# Altium schematic sheet Batt_Protection.SchDoc
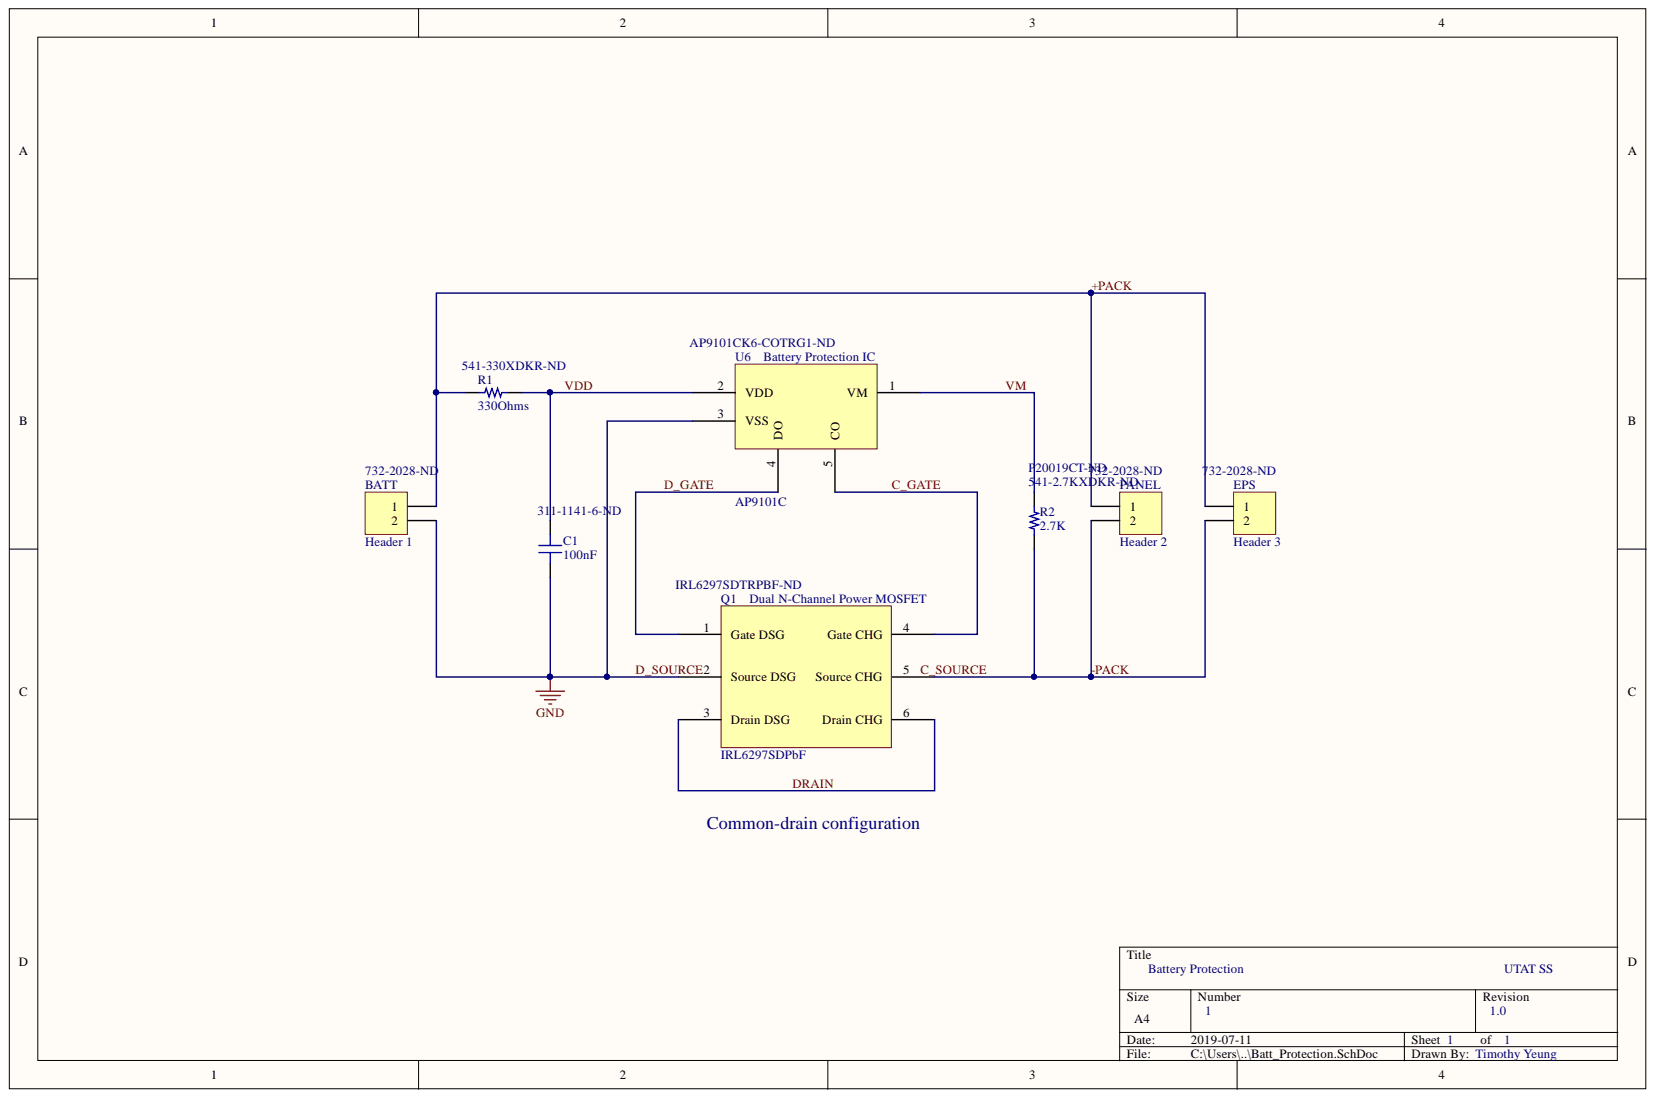
[diagram p0: Batt_Protection.SchDoc]

## Parts
BATT  Header 1  Supplier Part Number 1: 732-2028-ND  [SAM9552-ND]
C1  100nF  Supplier Part Number 1: 311-1141-6-ND  [1608[0603]]
EPS  Header 3  Supplier Part Number 1: 732-2028-ND  [CON2_SCREW_5.00MM_691102710002]
PANEL  Header 2  Supplier Part Number 1: 732-2028-ND  [CON2_SCREW_5.00MM_691102710002]
Q1  IRL6297SDPbF  FET Type: 2 N-Channel  Supplier Part Number 1: IRL6297SDTRPBF-ND  [IRL6297SDPbF]
R1  330Ohms  Supplier Part Number 1: 541-330XDKR-ND  [1608[0603]]
R2  2.7K  Supplier Part Number 1: 541-2.7KXDKR-ND  Supplier Part Number 2: P20019CT-ND  [1608[0603]]
U6  AP9101C  Supplier Part Number 1: AP9101CK6-COTRG1-ND  [AP9101C-776313]

## Nets
+PACK: BATT.1 EPS.1 PANEL.1 R1.1
-PACK: EPS.2 PANEL.2 Q1.5(Source_CHG) R2.1
C_GATE: Q1.4(Gate_CHG) U6.5(CO)
D_GATE: Q1.1(Gate_DSG) U6.4(DO)
D_SOURCE: BATT.2 C1.1 Q1.2(Source_DSG) U6.3(VSS)
DRAIN: Q1.3(Drain_DSG) Q1.6(Drain_CHG)
VDD: C1.2 R1.2 U6.2(VDD)
VM: R2.2 U6.1(VM)
C_SOURCE:

## Library data of the parts
BATT EPS PANEL = Header 2: Header, 2-Pin; Manufacturer: Wurth Electronics; Manufacturer Part Number: 691102710002; Current: 15A; Number of Contacts: 2; Number of Levels: 1; Pitch: 0.197inch; Plating: Steel; Stripping Length: -6mm; Voltage: 300V; Wire/Cable Gauge: 22AWG; Wire Gauge (Min): 14AWG; Color: Blue; Component Kind: Standard; ComponentLink1Description: https://katalog.we-online.de/em/datasheet/6911027100xx.pdf?src-supplier=Digi-Key; ComponentLink1URL: https://katalog.we-online.de/em/datasheet/6911027100xx.pdf?src-supplier=Digi-Key; ComponentLink2Description: http://www.produktinfo.conrad.com/datenblaetter/1000000-1099999/001088592-da-01-en-WR_TBL_TERMINAL_BLOCK102_691102710002.pdf?src-supplier=Conrad; ComponentLink2URL: http://www.produktinfo.conrad.com/datenblaetter/1000000-1099999/001088592-da-01-en-WR_TBL_TERMINAL_BLOCK102_691102710002.pdf?src-supplier=Conrad; Contact Material: Brass; Contact Plating: Tin; Current Rating: 15A; Height: 13.4mm; Housing Material: Nylon; LatestRevisionDate: 17-Jul-2002; LatestRevisionNote: Re-released for DXP Platform.; Length: 7.5mm; Library Name: Miscellaneous Connectors.IntLib; Max Operating Temperature: 105°C; Min Operating Temperature: -40°C; Mount: Through Hole; Number of Positions Per Level: 2; Package Quantity: 900; Packaging: Bulk; Pricing 1: 1=0.69, 10=0.616, 50=0.532, 100=0.5 (USD); REACH SVHC: Unknown; Signal Integrity: Connector; Stock 1: 734; Supplier 1: Digi-Key; Termination: Screw; Voltage Rating: 300V; Width: 11.2mm; Wire Gauge (Max): 22AWG; Publisher: Altium Limited
C1 = Capacitor: SMT Capacitor; Manufacturer: Yageo; Manufacturer Part Number: CC0805KRX7R8BB104; Dielectric: X7R; Insulation Resistance: 100GR; Material: Ceramic; Tolerance: 10%; Value: 0.1uF; Voltage Rating: 25V; Capacitance: 100nF; Case/Package: 0805; Case Code (Imperial): 0805; Case Code (Metric): 2012; Component Kind: Standard; ComponentLink1Description: http://www.yageo.com/documents/recent/UPY-GPHC_X7R_6.3V-to-50V_18.pdf?src-supplier=Digi-Key; ComponentLink1URL: http://www.yageo.com/documents/recent/UPY-GPHC_X7R_6.3V-to-50V_18.pdf?src-supplier=Digi-Key; ComponentLink2Description: http://www.farnell.com/datasheets/1489800.pdf?src-supplier=Element14; ComponentLink2URL: http://www.farnell.com/datasheets/1489800.pdf?src-supplier=Element14; ComponentLink3Description: http://www.farnell.com/datasheets/1850775.pdf?src-supplier=Element14; ComponentLink3URL: http://www.farnell.com/datasheets/1850775.pdf?src-supplier=Element14; ComponentLink4Description: https://www.verical.com/server-webapp/html/getDatasheet?partID=330244&src-supplier=Verical; ComponentLink4URL: https://www.verical.com/server-webapp/html/getDatasheet?partID=330244&src-supplier=Verical; ComponentLink5Description: http://www.yageo.com/documents/recent/UPY-GPHC_X7R_6.3V-to-50V_8.pdf?src-supplier=Avnet+Europe; ComponentLink5URL: http://www.yageo.com/documents/recent/UPY-GPHC_X7R_6.3V-to-50V_8.pdf?src-supplier=Avnet+Europe; ComponentLink6Description: http://www.farnell.com/datasheets/1784427.pdf?src-supplier=Element14; ComponentLink6URL: http://www.farnell.com/datasheets/1784427.pdf?src-supplier=Element14; ComponentLink7Description: http://www.farnell.com/datasheets/70765.pdf?src-supplier=Element14; ComponentLink7URL: http://www.farnell.com/datasheets/70765.pdf?src-supplier=Element14; Composition: Ceramic; Depth: 1.25mm; Halogen Free: Halogen Free; Height: 0.85mm; Lead Free: Lead Free; Length: 2mm; Library Name: Passives.SchLib; Max Operating Temperature: 125°C; Min Operating Temperature: -55°C; Mount: Surface Mount; Number of Pins: 2; Package Quantity: 1; Packaging: Tape and Reel; Pricing 1: 1=0.13, 10=0.092, 100=0.0433, 500=0.0304, 1000=0.02516 (USD); Radiation Hardening: No; REACH SVHC: No SVHC; Stock 1: 1166693; Supplier 1: Digi-Key; Termination: SMD/SMT; Thickness: 0.033inch; Voltage Rating (DC): 25V; Width: 0.049inch
Q1 = IRL6297SDPbF: Dual N-Channel Power MOSFET; Manufacturer: International Rectifier; Manufacturer Part Number: IRL6297SDTRPBF; Continuous Drain Current (ID): 15A; Drain to Source Voltage (Vdss): 20V; Input Capacitance: 2.245nF; Max Power Dissipation: 1.7W; Number of Elements: 2; Rds On Max: 4.9mR; Threshold Voltage: 800mV; Component Kind: Standard; ComponentLink1Description: http://www.irf.com/product-info/datasheets/data/irl6297sdpbf.pdf?src-supplier=Digi-Key; ComponentLink1URL: http://www.irf.com/product-info/datasheets/data/irl6297sdpbf.pdf?src-supplier=Digi-Key; Gate to Source Voltage (Vgs): 20V; Lead Free: Lead Free; Library Name: EPS.SchLib; Max Operating Temperature: 150°C; Min Operating Temperature: -40°C; Mount: Surface Mount; Number of Pins: 8; Package Quantity: 4800; Packaging: Tape and Reel; Power Dissipation: 1.7W; REACH SVHC: No SVHC; Stock 1: 0; Supplier 1: Digi-Key
R1 = Resistor: SMT Resistor; Manufacturer: Vishay Dale; Manufacturer Part Number: CRCW2512330RJNEG; Max Power Dissipation: 1W; Resistance: 330R; Temperature Coefficient: 200ppm/°C; Tolerance: 5%; Value: 1K; Voltage Rating: 500V; Case/Package: 2512; Case Code (Imperial): 2512; Case Code (Metric): 6432; Component Kind: Standard; ComponentLink1Description: http://www.vishay.com/docs/20035/dcrcwe3.pdf?src-supplier=Digi-Key; ComponentLink1URL: http://www.vishay.com/docs/20035/dcrcwe3.pdf?src-supplier=Digi-Key; ComponentLink2Description: http://www.vishay.com/docs/20035/dcrcwe3.pdf?src-supplier=Avnet; ComponentLink2URL: http://www.vishay.com/docs/20035/dcrcwe3.pdf?src-supplier=Avnet; ComponentLink3Description: https://www.alliedelec.com/m/d/0c934d6939ccefa68dbe0b96d821c8cf.pdf?src-supplier=Allied+Electronics; ComponentLink3URL: https://www.alliedelec.com/m/d/0c934d6939ccefa68dbe0b96d821c8cf.pdf?src-supplier=Allied+Electronics; ComponentLink4Description: http://www.vishay.com/docs/20035/dcrcwe3.pdf?src-supplier=Future+Electronics; ComponentLink4URL: http://www.vishay.com/docs/20035/dcrcwe3.pdf?src-supplier=Future+Electronics; ComponentLink5Description: http://www.farnell.com/datasheets/1847332.pdf?src-supplier=Element14; ComponentLink5URL: http://www.farnell.com/datasheets/1847332.pdf?src-supplier=Element14; ComponentLink6Description: http://www.mouser.com/ds/2/427/dcrcwe3-109170.pdf?src-supplier=Mouser; ComponentLink6URL: http://www.mouser.com/ds/2/427/dcrcwe3-109170.pdf?src-supplier=Mouser; Composition: Thick Film; Contact Plating: Tin; Depth: 3.15mm; Features: Automotive AEC-Q200; Height: 0.6mm; Lead Free: Lead Free; Length: 6.3mm; Library Name: Passives.SchLib; Max Operating Temperature: 155°C; Military Standard: Not; Min Operating Temperature: -55°C; Mount: Surface Mount; Number of Pins: 2; Number of Terminations: 2; Package Quantity: 1; Packaging: Tape and Reel; Power Rating: 1W; Pricing 1: 1=0.38, 10=0.318, 100=0.1242, 1000=0.05198 (USD); Radiation Hardening: No; REACH SVHC: Unknown; Stock 1: 42562; Supplier 1: Digi-Key; Termination: Solder; Voltage Rating (DC): 500V; Weight: 0.001429oz; Width: 0.124inch
R2 = Resistor: SMT Resistor; Manufacturer: Vishay Dale; Manufacturer Part Number: CRCW25122K70JNEG; Max Power Dissipation: 1W; Resistance: 2.7kR; Temperature Coefficient: 200ppm/°C; Tolerance: 5%; Value: 1K; Voltage Rating: 500V; Case/Package: 2512; Case Code (Imperial): 2512; Case Code (Metric): 6432; Component Kind: Standard; ComponentLink1Description: http://www.mouser.com/ds/2/427/dcrcwe3-109170.pdf?src-supplier=Mouser; ComponentLink1URL: http://www.mouser.com/ds/2/427/dcrcwe3-109170.pdf?src-supplier=Mouser; ComponentLink2Description: http://www.vishay.com/docs/20035/dcrcwe3.pdf?src-supplier=Avnet; ComponentLink2URL: http://www.vishay.com/docs/20035/dcrcwe3.pdf?src-supplier=Avnet; ComponentLink3Description: http://www.farnell.com/datasheets/2045674.pdf?src-supplier=Element14; ComponentLink3URL: http://www.farnell.com/datasheets/2045674.pdf?src-supplier=Element14; ComponentLink4Description: http://www.vishay.com/docs/20035/dcrcwe3.pdf?src-supplier=Digi-Key; ComponentLink4URL: http://www.vishay.com/docs/20035/dcrcwe3.pdf?src-supplier=Digi-Key; Composition: Thick Film; Contact Plating: Tin; Depth: 3.15mm; Features: Automotive AEC-Q200; Height: 0.028inch; Height - Seated (Max): 0.022inch; Lead Free: Lead Free; Length: 6.3mm; Library Name: Passives.SchLib; Max Operating Temperature: 155°C; Military Standard: Not; Min Operating Temperature: -55°C; Number of Pins: 2; Number of Terminations: 2; Package Quantity: 2000; Packaging: Tape and Reel; Power Rating: 1W; Pricing 1: 1=0.38, 10=0.318, 100=0.1242, 1000=0.05198 (USD); Pricing 2: 1=0.25, 10=0.211, 25=0.1532, 50=0.111, 100=0.0823, 250=0.06316, 500=0.04976, 1000=0.03445 (USD); Radiation Hardening: No; REACH SVHC: Unknown; Stock 1: 6589; Stock 2: 1266; Supplier 1: Digi-Key; Supplier 2: Digi-Key; Termination: SMD/SMT; Voltage Rating (DC): 500V; Weight: 0.001429oz; Width: 3.15mm
U6 = AP9101C: Battery Protection IC; Manufacturer: Diodes; Manufacturer Part Number: AP9101CK6-COTRG1; Case/Package: SOT-23-6; Component Kind: Standard; Library Name: EPS.SchLib; Packaging: Tape and Reel; Pricing 1: 3000=0.12375 (USD); Stock 1: 0; Supplier 1: Digi-Key
